# Altium schematic sheet 06_CEP3V3.SchDoc(06_CEP3V3)
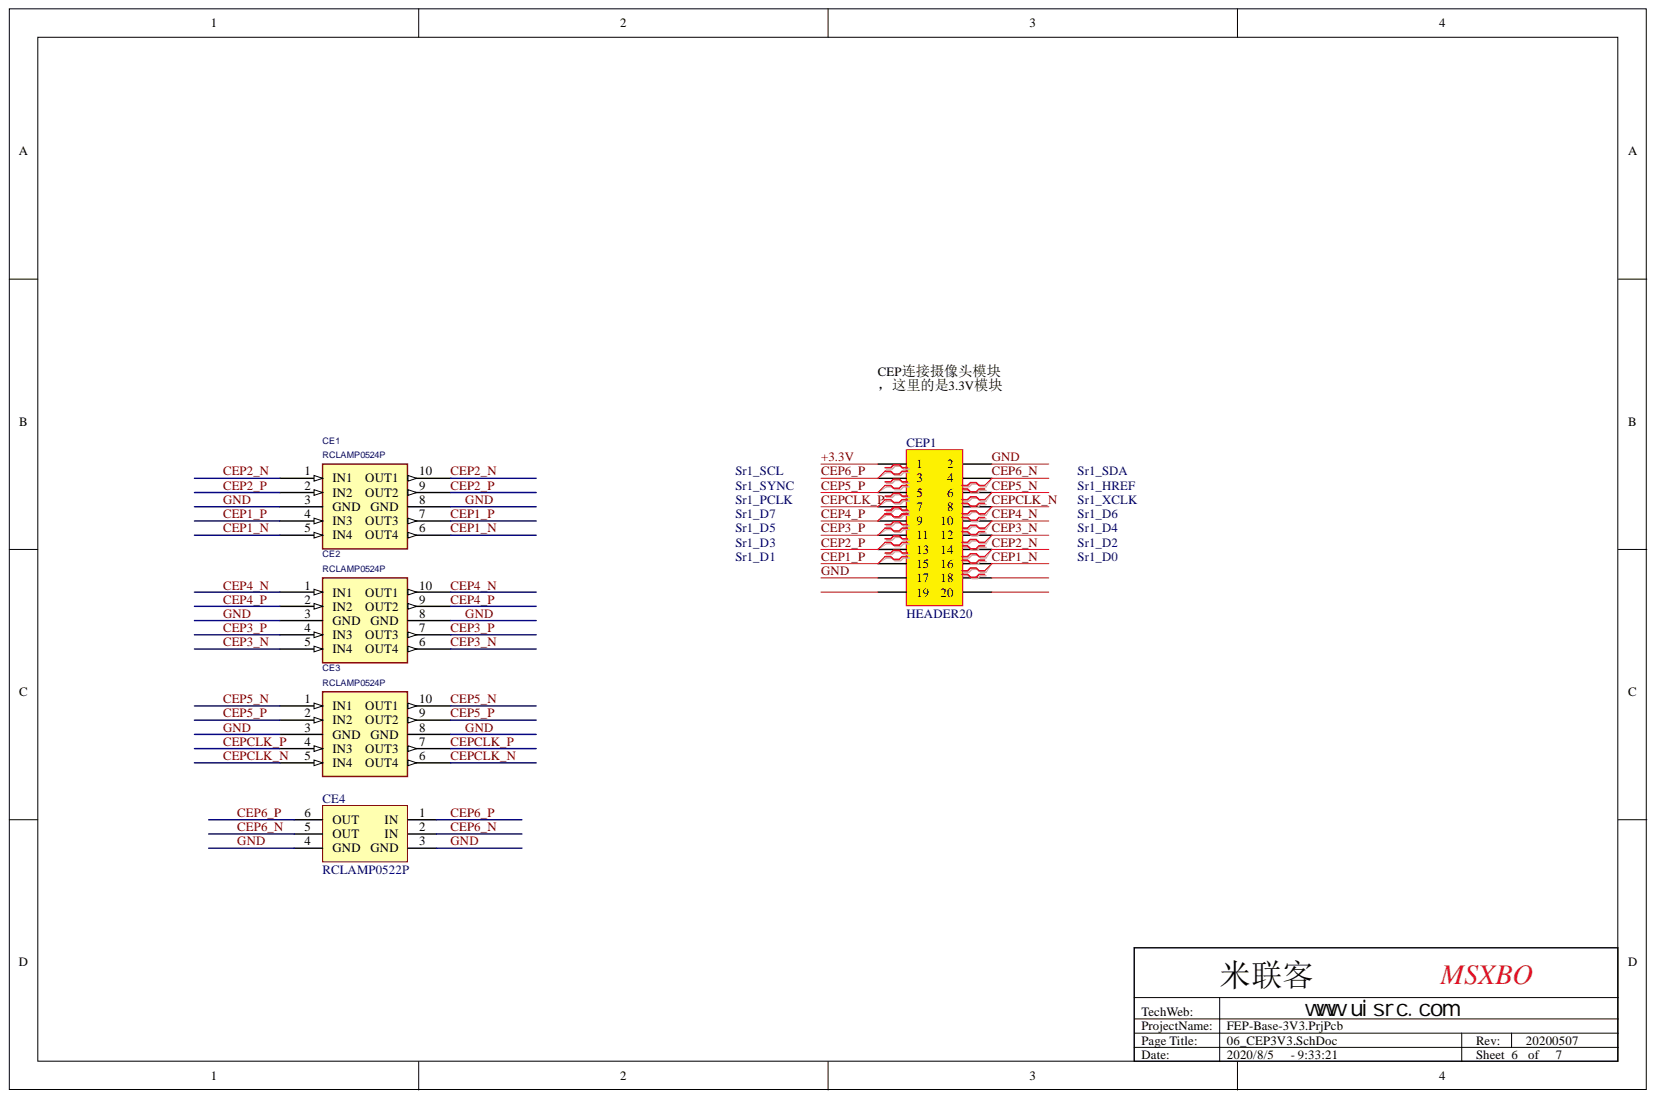
[diagram p0: 06_CEP3V3.SchDoc]

## Parts
CE1  RCLAMP0524P  [SLP2510P8_10]
CE2  RCLAMP0524P  [SLP2510P8_10]
CE3  RCLAMP0524P  [SLP2510P8_10]
CE4  RCLAMP0522P  [SLP1610P4_6]
CEP1  HEADER20  [HEADER10X2X2.5]

## Nets
+3.3V: CEP1.1
CEP1_N: CE1.5(IN4) CE1.6(OUT4) CEP1.16
CEP1_P: CE1.4(IN3) CE1.7(OUT3) CEP1.15
CEP2_N: CE1.1(IN1) CE1.10(OUT1) CEP1.14
CEP2_P: CE1.2(IN2) CE1.9(OUT2) CEP1.13
CEP3_N: CE2.5(IN4) CE2.6(OUT4) CEP1.12
CEP3_P: CE2.4(IN3) CE2.7(OUT3) CEP1.11
CEP4_N: CE2.1(IN1) CE2.10(OUT1) CEP1.10
CEP4_P: CE2.2(IN2) CE2.9(OUT2) CEP1.9
CEP5_N: CE3.1(IN1) CE3.10(OUT1) CEP1.6
CEP5_P: CE3.2(IN2) CE3.9(OUT2) CEP1.5
CEP6_N: CE4.2(IN) CE4.5(OUT) CEP1.4
CEP6_P: CE4.1(IN) CE4.6(OUT) CEP1.3
CEPCLK_N: CE3.5(IN4) CE3.6(OUT4) CEP1.8
CEPCLK_P: CE3.4(IN3) CE3.7(OUT3) CEP1.7
GND: CE1.3(GND) CE1.8(GND) CE2.3(GND) CE2.8(GND) CE3.3(GND) CE3.8(GND) CE4.3(GND) CE4.4(GND) CEP1.2 CEP1.17
SR1_D0:
SR1_D1:
SR1_D2:
SR1_D3:
SR1_D4:
SR1_D5:
SR1_D6:
SR1_D7:
SR1_HREF:
SR1_PCLK:
SR1_SCL:
SR1_SDA:
SR1_SYNC:
SR1_XCLK:

Not connected: CEP1 18 19 20

## Library data of the parts
CEP1 = HEADER10X2: Component Kind: Standard; Library Name: jinrylib.IntLib
CE1-CE3 = RCLAMP0524P: Component Kind: Standard
CE4 = RCLAMP0522P: Component Kind: Standard; Library Name: MiZ7020N_定制.SCHLIB
